# Altium schematic sheet 03 MCU.SchDoc
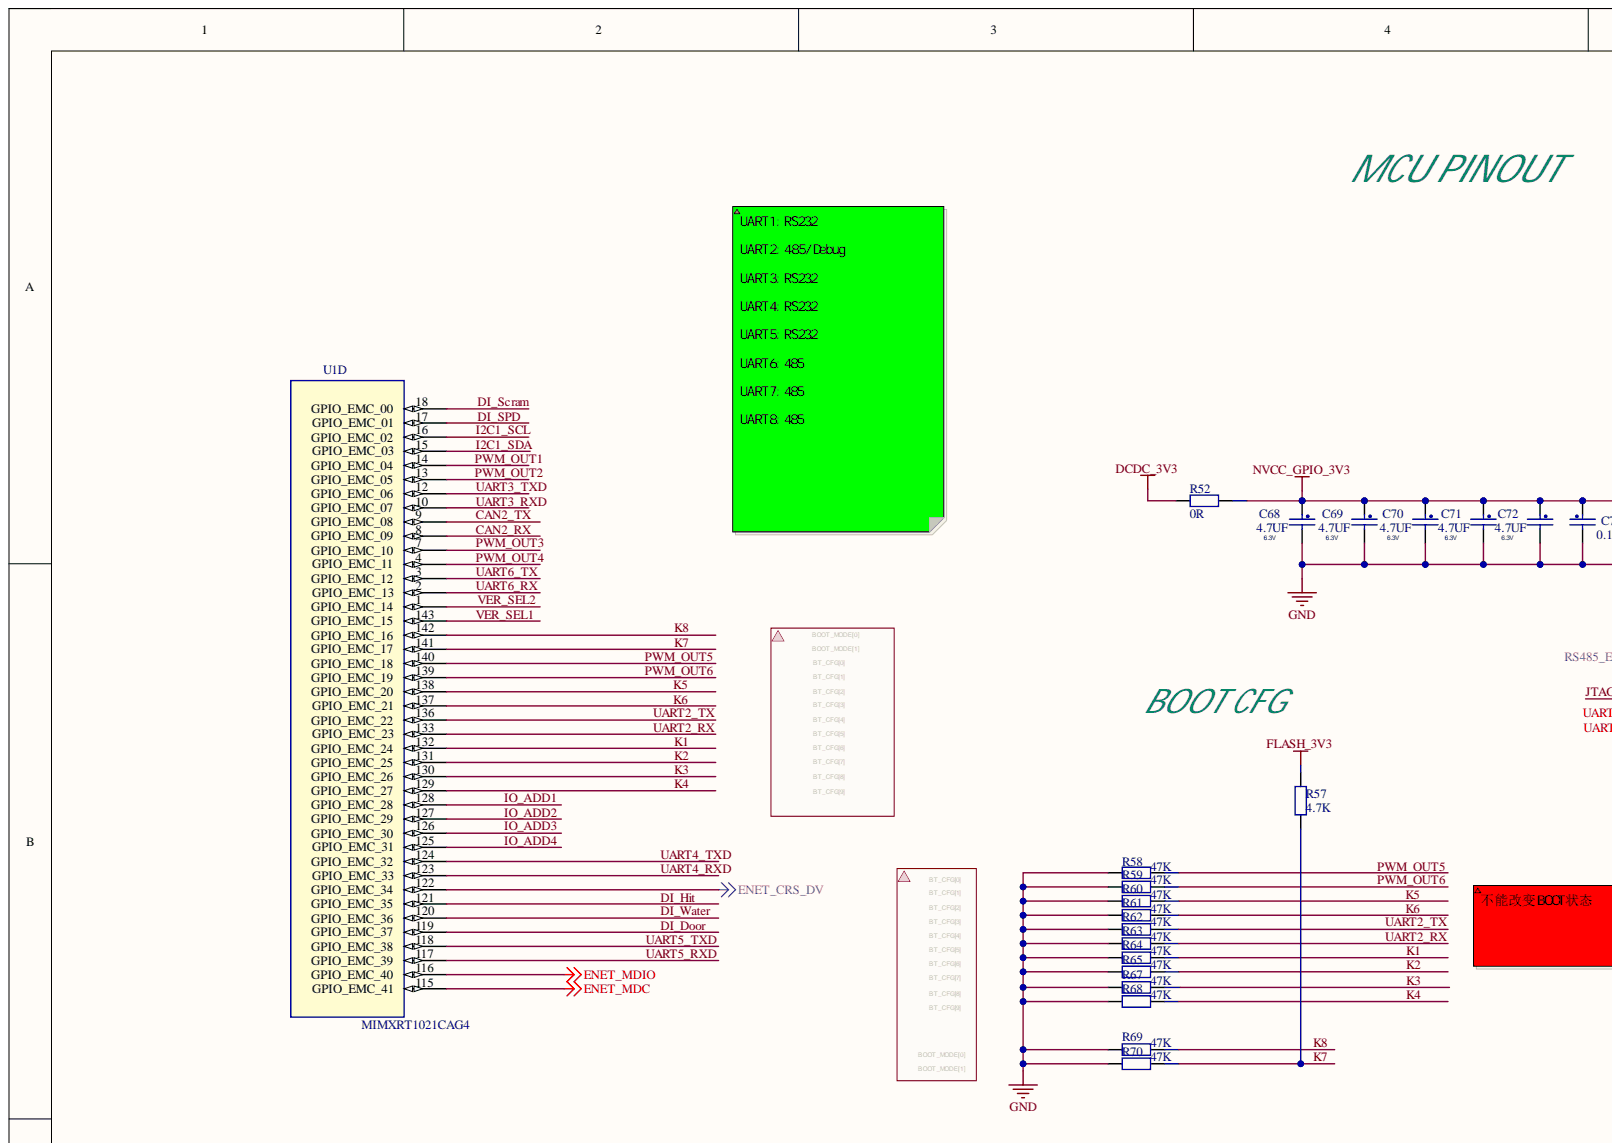
[diagram p0: 03 MCU.SchDoc, top left]
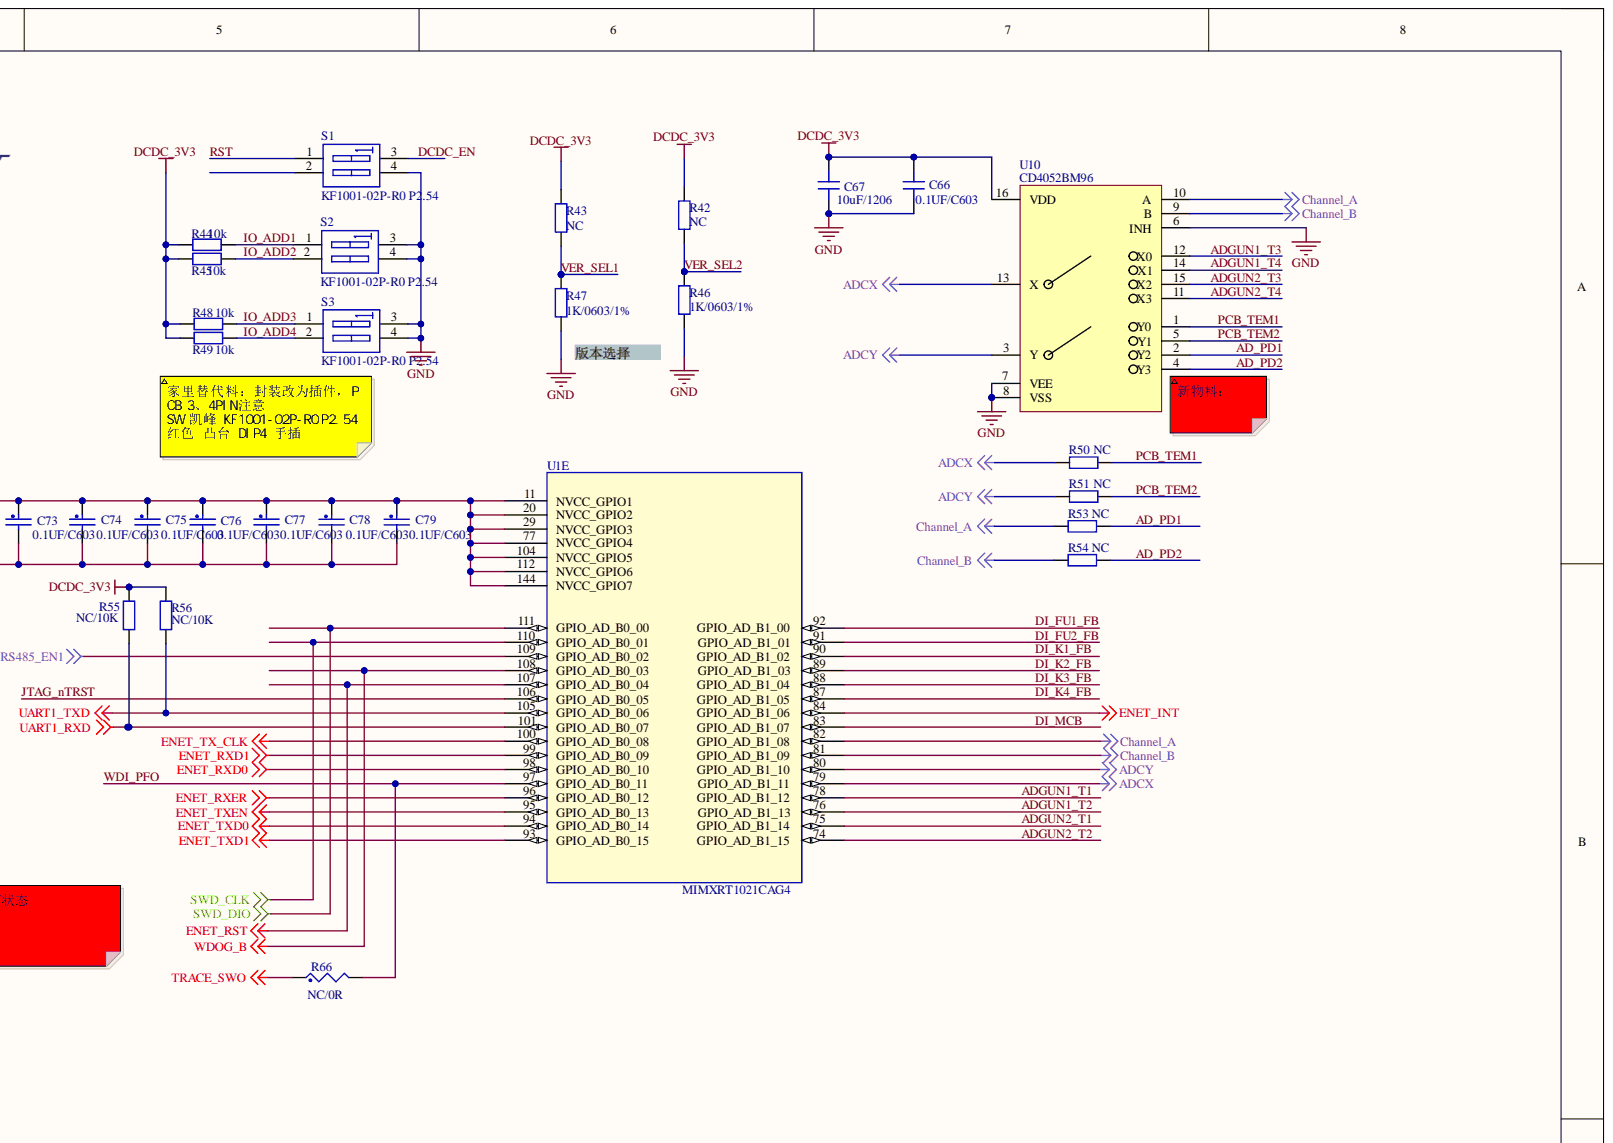
[diagram p1: 03 MCU.SchDoc, top right]
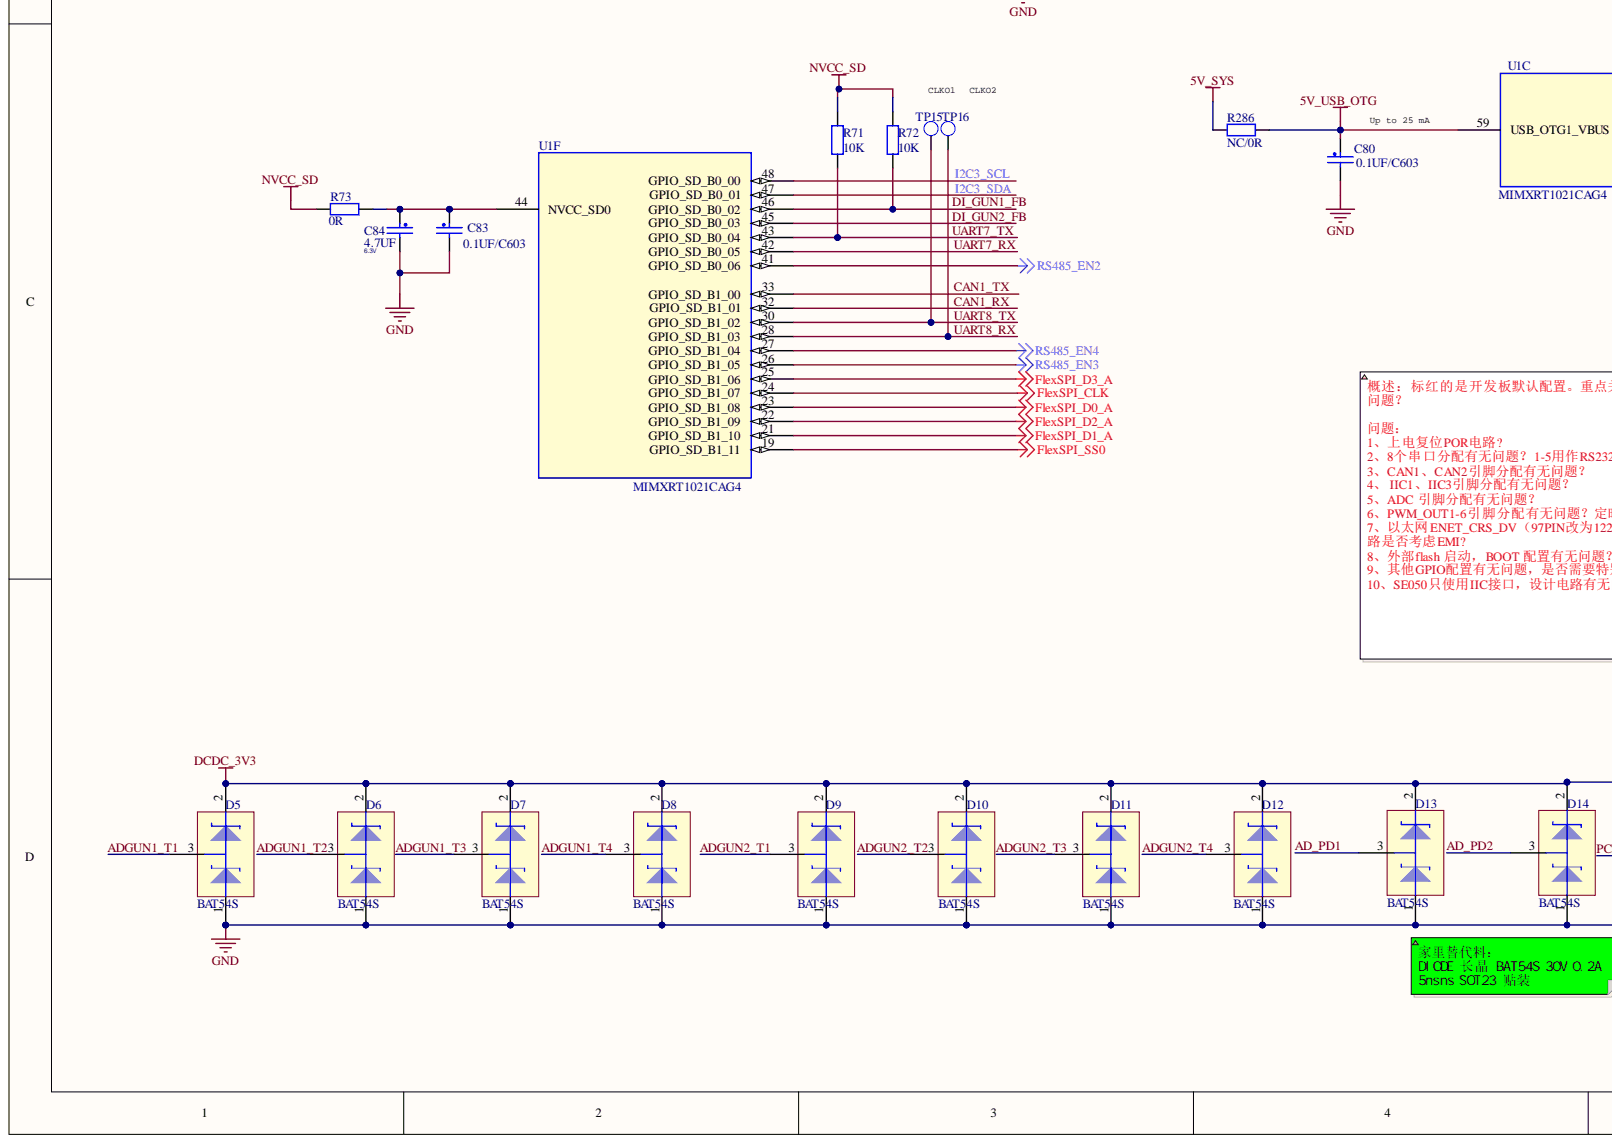
[diagram p2: 03 MCU.SchDoc, bottom left]
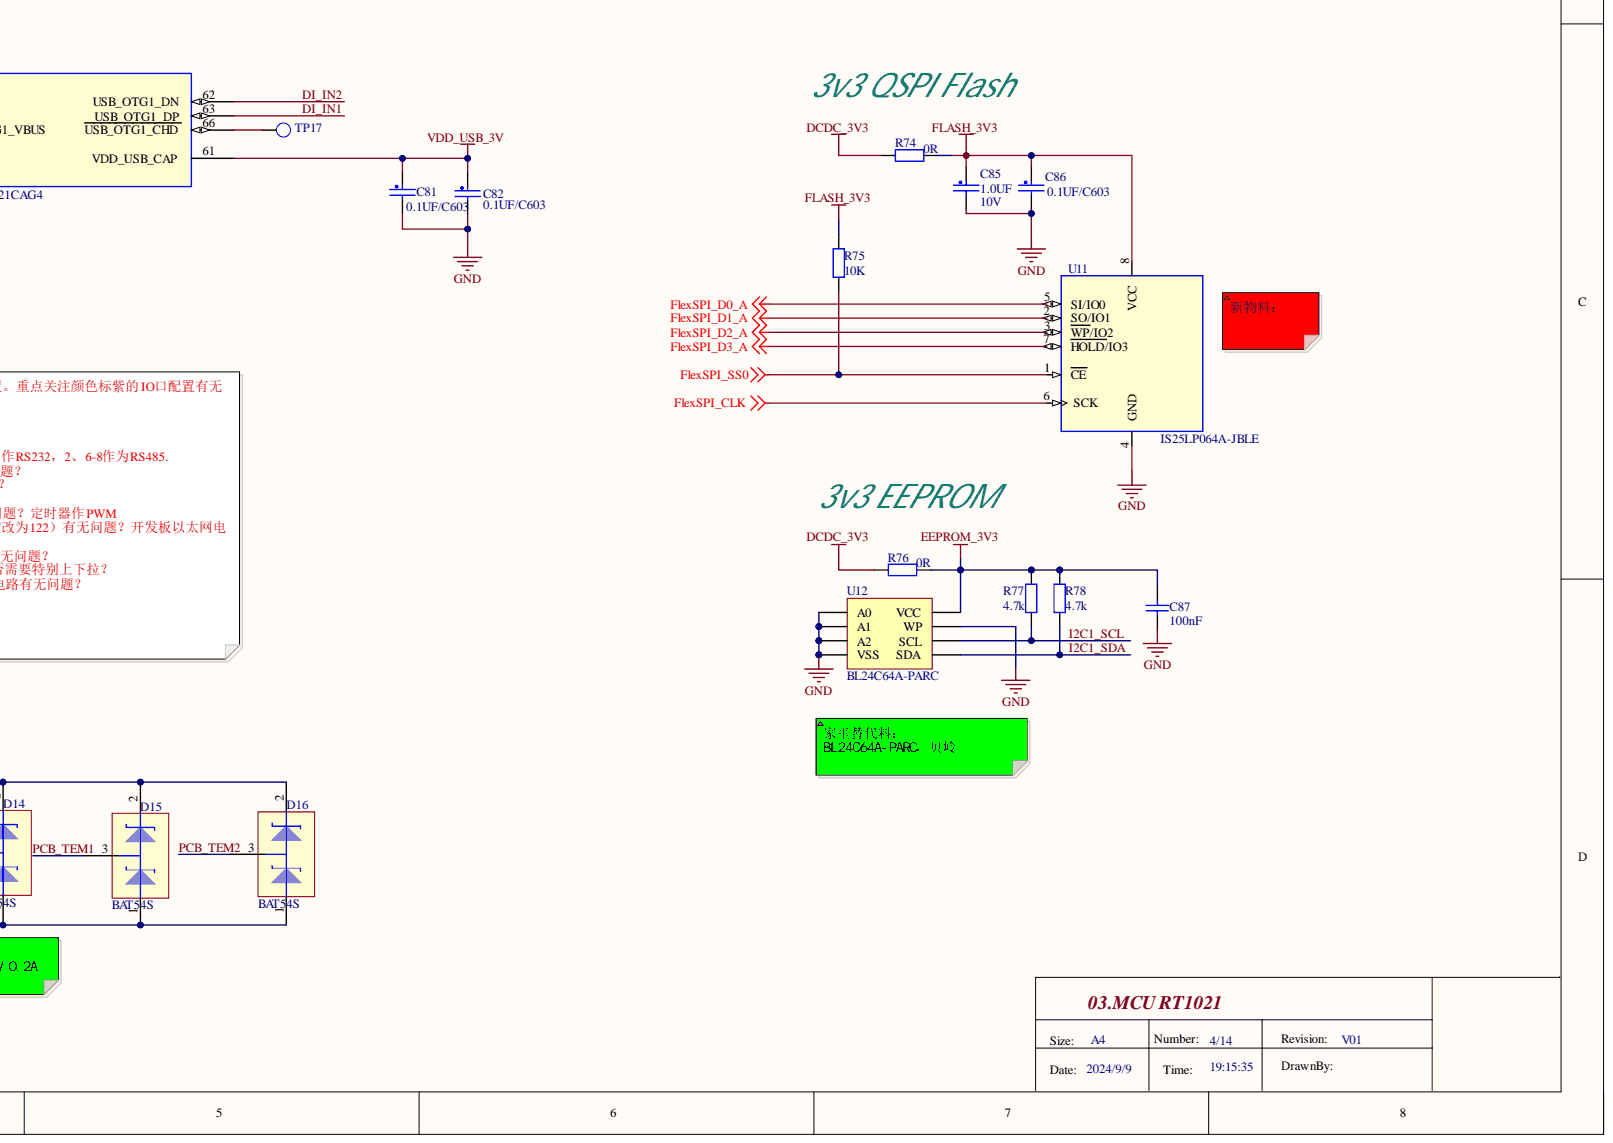
[diagram p3: 03 MCU.SchDoc, bottom right]

## Parts
C66  0.1UF/C603  [C0603]
C67  10uF/1206  [C1206]
C68  4.7UF  VOLTAGE: 6.3V  [C0805]
C69  4.7UF  VOLTAGE: 6.3V  [C0805]
C70  4.7UF  VOLTAGE: 6.3V  [C0805]
C71  4.7UF  VOLTAGE: 6.3V  [C0805]
C72  4.7UF  VOLTAGE: 6.3V  [C0805]
C73  0.1UF/C603  [C0603]
C74  0.1UF/C603  [C0603]
C75  0.1UF/C603  [C0603]
C76  0.1UF/C603  [C0603]
C77  0.1UF/C603  [C0603]
C78  0.1UF/C603  [C0603]
C79  0.1UF/C603  [C0603]
C80  0.1UF/C603  [C0603]
C81  0.1UF/C603  [C0603]
C82  0.1UF/C603  [C0603]
C83  0.1UF/C603  [C0603]
C84  4.7UF  VOLTAGE: 6.3V  [C0805]
C85  1.0UF  VOLTAGE: 10V  [C0805]
C86  0.1UF/C603  [C0603]
C87  100nF  [C0603]
D5  BAT54S  [SOT-23]
D6  BAT54S  [SOT-23]
D7  BAT54S  [SOT-23]
D8  BAT54S  [SOT-23]
D9  BAT54S  [SOT-23]
D10  BAT54S  [SOT-23]
D11  BAT54S  [SOT-23]
D12  BAT54S  [SOT-23]
D13  BAT54S  [SOT-23]
D14  BAT54S  [SOT-23]
D15  BAT54S  [SOT-23]
D16  BAT54S  [SOT-23]
R42  NC  [R0603]
R43  NC  [R0603]
R44  10k  [R0603]  (value not drawn)
R45  10k  [R0603]
R46  1K/0603/1%  [R0603]
R47  1K/0603/1%  [R0603]
R48  10k  [R0603]
R49  10k  [R0603]
R50  NC  [R0603]
R51  NC  [R0603]
R52  0R  [R0603]
R53  NC  [R0603]
R54  NC  [R0603]
R55  NC/10K  [R0603]
R56  NC/10K  [R0603]
R57  4.7K  [R0603]
R58  47K  [R0603]
R59  47K  [R0603]
R60  47K  [R0603]
R61  47K  [R0603]
R62  47K  [R0603]
R63  47K  [R0603]
R64  47K  [R0603]
R65  47K  [R0603]
R66  NC/0R  [R0603]
R67  47K  [R0603]
R68  47K  [R0603]
R69  47K  [R0603]
R70  47K  [R0603]
R71  10K  [R0603]
R72  10K  [R0603]
R73  0R  [R0603]
R74  0R  [R0603]
R75  10K  [R0603]
R76  0R  [R0603]
R77  4.7k  [R0603]
R78  4.7k  [R0603]
R286  NC/0R  [R0603]
S1  KF1001-02P-R0 P2.54  [DMR-02]
S2  KF1001-02P-R0 P2.54  [DMR-02]
S3  KF1001-02P-R0 P2.54  [DMR-02]
TP15  TP_35MIL  [TP12]  (value not drawn)
TP16  TP_35MIL  [TP12]  (value not drawn)
TP17  TP_35MIL  [TP12]  (value not drawn)
U1  MIMXRT1021CAG4  [LQFP-144_MIMXRT1021CAG4]  (parts C,D,E,F)
U10  CD4052BM96  [SOIC-16_150MIL]
U11  IS25LP064A-JBLE  [SOIC-8_208mil]
U12  BL24C64A-PARC  [SOIC-8_150mil]

## Nets
5V_SYS: R286.1
5V_USB_OTG: C80.1 U1.59(USB_OTG1_VBUS)
ADCX: R50.1 U1.79(GPIO_AD_B1_11) U10.13(X)
ADCY: R51.1 U1.80(GPIO_AD_B1_10) U10.3(Y)
Channel_A: R53.1 U1.82(GPIO_AD_B1_08) U10.10(A)
Channel_B: R54.1 U1.81(GPIO_AD_B1_09) U10.9(B)
DCDC_3V3: C66.2 C67.2 D5.2 D6.2 D7.2 D8.2 D9.2 D10.2 D11.2 D12.2 D13.2 D14.2 D15.2 D16.2 R42.1 R43.1 R44.1 R45.1 R48.1 R49.1 R52.1 R55.2 R56.2 R74.1 R76.1 U10.16(VDD)
EEPROM_3V3: C87.2 R76.2 R77.2 R78.2 U12.8(VCC)
ENET_CRS_DV: U1.122(GPIO_EMC_34)
ENET_INT: U1.84(GPIO_AD_B1_06)
ENET_MDC: U1.115(GPIO_EMC_41)
ENET_MDIO: U1.116(GPIO_EMC_40)
ENET_RST: U1.107(GPIO_AD_B0_04)
ENET_RXD0: U1.98(GPIO_AD_B0_10)
ENET_RXD1: U1.99(GPIO_AD_B0_09)
ENET_RXER: U1.96(GPIO_AD_B0_12)
ENET_TX_CLK: U1.100(GPIO_AD_B0_08)
ENET_TXD0: U1.94(GPIO_AD_B0_14)
ENET_TXD1: U1.93(GPIO_AD_B0_15)
ENET_TXEN: U1.95(GPIO_AD_B0_13)
FLASH_3V3: C85.1 C86.1 R57.2 R74.2 R75.2 U11.8(VCC)
FlexSPI_CLK: U1.24(GPIO_SD_B1_07) U11.6(SCK)
FlexSPI_D0_A: U1.23(GPIO_SD_B1_08) U11.5(SI/IO0)
FlexSPI_D1_A: U1.21(GPIO_SD_B1_10) U11.2(SO/IO1)
FlexSPI_D2_A: U1.22(GPIO_SD_B1_09) U11.3(WP/IO2)
FlexSPI_D3_A: U1.25(GPIO_SD_B1_06) U11.7(HOLD/IO3)
FlexSPI_SS0: R75.1 U1.19(GPIO_SD_B1_11) U11.1(CE)
GND: C66.1 C67.1 C68.2 C69.2 C70.2 C71.2 C72.2 C73.2 C74.2 C75.2 C76.2 C77.2 C78.2 C79.2 C80.2 C81.2 C82.2 C83.2 C84.2 C85.2 C86.2 C87.1 D5.1 D6.1 D7.1 D8.1 D9.1 D10.1 D11.1 D12.1 D13.1 D14.1 D15.1 D16.1 R46.2 R47.2 R58.1 R59.1 R60.1 R61.1 R62.1 R63.1 R64.1 R65.1 R67.1 R68.1 R69.1 R70.1 S1.4 S2.3 S2.4 S3.3 S3.4 U10.6(INH) U10.7(VEE) U10.8(VSS) U11.4(GND) U12.1(A0) U12.2(A1) U12.3(A2) U12.4(VSS) U12.7(WP)
NVCC_GPIO_3V3: C68.1 C69.1 C70.1 C71.1 C72.1 C73.1 C74.1 C75.1 C76.1 C77.1 C78.1 C79.1 R52.2 U1.11(NVCC_GPIO1) U1.20(NVCC_GPIO2) U1.29(NVCC_GPIO3) U1.77(NVCC_GPIO4) U1.104(NVCC_GPIO5) U1.112(NVCC_GPIO6) U1.144(NVCC_GPIO7)
NVCC_SD: R71.2 R72.2 R73.1
RS485_EN1: U1.109(GPIO_AD_B0_02)
RS485_EN2: U1.41(GPIO_SD_B0_06)
RS485_EN3: U1.26(GPIO_SD_B1_05)
RS485_EN4: U1.27(GPIO_SD_B1_04)
SWD_CLK: U1.110(GPIO_AD_B0_01)
SWD_DIO: U1.111(GPIO_AD_B0_00)
TRACE_SWO: R66.1
UART1_RXD: R55.1 U1.101(GPIO_AD_B0_07)
UART1_TXD: R56.1 U1.105(GPIO_AD_B0_06)
VDD_USB_3V: C81.1 C82.1 U1.61(VDD_USB_CAP)
WDOG_B: U1.108(GPIO_AD_B0_03)
AD_PD1: D13.3 R53.2 U10.2(Y2)
AD_PD2: D14.3 R54.2 U10.4(Y3)
ADGUN1_T1: D5.3 U1.78(GPIO_AD_B1_12)
ADGUN1_T2: D6.3 U1.76(GPIO_AD_B1_13)
ADGUN1_T3: D7.3 U10.12(X0)
ADGUN1_T4: D8.3 U10.14(X1)
ADGUN2_T1: D9.3 U1.75(GPIO_AD_B1_14)
ADGUN2_T2: D10.3 U1.74(GPIO_AD_B1_15)
ADGUN2_T3: D11.3 U10.15(X2)
ADGUN2_T4: D12.3 U10.11(X3)
CAN1_RX: U1.32(GPIO_SD_B1_01)
CAN1_TX: U1.33(GPIO_SD_B1_00)
CAN2_RX: U1.8(GPIO_EMC_09)
CAN2_TX: U1.9(GPIO_EMC_08)
DCDC_EN: S1.3
DI_Door: U1.119(GPIO_EMC_37)
DI_FU1_FB: U1.92(GPIO_AD_B1_00)
DI_FU2_FB: U1.91(GPIO_AD_B1_01)
DI_GUN1_FB: R72.1 U1.46(GPIO_SD_B0_02)
DI_GUN2_FB: U1.45(GPIO_SD_B0_03)
DI_Hit: U1.121(GPIO_EMC_35)
DI_IN1: U1.63(USB_OTG1_DP)
DI_IN2: U1.62(USB_OTG1_DN)
DI_K1_FB: U1.90(GPIO_AD_B1_02)
DI_K2_FB: U1.89(GPIO_AD_B1_03)
DI_K3_FB: U1.88(GPIO_AD_B1_04)
DI_K4_FB: U1.87(GPIO_AD_B1_05)
DI_MCB: U1.83(GPIO_AD_B1_07)
DI_Scram: U1.18(GPIO_EMC_00)
DI_SPD: U1.17(GPIO_EMC_01)
DI_Water: U1.120(GPIO_EMC_36)
I2C1_SCL: R77.1 U1.16(GPIO_EMC_02) U12.6(SCL)
I2C1_SDA: R78.1 U1.15(GPIO_EMC_03) U12.5(SDA)
I2C3_SCL: U1.48(GPIO_SD_B0_00)
I2C3_SDA: U1.47(GPIO_SD_B0_01)
IO_ADD1: R44.2 S2.1 U1.128(GPIO_EMC_28)
IO_ADD2: R45.2 S2.2 U1.127(GPIO_EMC_29)
IO_ADD3: R48.2 S3.1 U1.126(GPIO_EMC_30)
IO_ADD4: R49.2 S3.2 U1.125(GPIO_EMC_31)
JTAG_nTRST: U1.106(GPIO_AD_B0_05)
K1: R64.2 U1.132(GPIO_EMC_24)
K2: R65.2 U1.131(GPIO_EMC_25)
K3: R67.2 U1.130(GPIO_EMC_26)
K4: R68.2 U1.129(GPIO_EMC_27)
K5: R60.2 U1.138(GPIO_EMC_20)
K6: R61.2 U1.137(GPIO_EMC_21)
K7: R57.1 R70.2 U1.141(GPIO_EMC_17)
K8: R69.2 U1.142(GPIO_EMC_16)
PCB_TEM1: D15.3 R50.2 U10.1(Y0)
PCB_TEM2: D16.3 R51.2 U10.5(Y1)
PWM_OUT1: U1.14(GPIO_EMC_04)
PWM_OUT2: U1.13(GPIO_EMC_05)
PWM_OUT3: U1.7(GPIO_EMC_10)
PWM_OUT4: U1.4(GPIO_EMC_11)
PWM_OUT5: R58.2 U1.140(GPIO_EMC_18)
PWM_OUT6: R59.2 U1.139(GPIO_EMC_19)
RST: S1.1
UART2_RX: R63.2 U1.133(GPIO_EMC_23)
UART2_TX: R62.2 U1.136(GPIO_EMC_22)
UART3_RXD: U1.10(GPIO_EMC_07)
UART3_TXD: U1.12(GPIO_EMC_06)
UART4_RXD: U1.123(GPIO_EMC_33)
UART4_TXD: U1.124(GPIO_EMC_32)
UART5_RXD: U1.117(GPIO_EMC_39)
UART5_TXD: U1.118(GPIO_EMC_38)
UART6_RX: U1.2(GPIO_EMC_13)
UART6_TX: U1.3(GPIO_EMC_12)
UART7_RX: U1.42(GPIO_SD_B0_05)
UART7_TX: R71.1 U1.43(GPIO_SD_B0_04)
UART8_RX: TP16.1 U1.28(GPIO_SD_B1_03)
UART8_TX: TP15.1 U1.30(GPIO_SD_B1_02)
VER_SEL1: R43.2 R47.1 U1.143(GPIO_EMC_15)
VER_SEL2: R42.2 R46.1 U1.1(GPIO_EMC_14)
WDI_PFO: R66.2 U1.97(GPIO_AD_B0_11)
NetC83_1: C83.1 C84.1 R73.2 U1.44(NVCC_SD0)
NetTP17_1: TP17.1 U1.66(USB_OTG1_CHD)
BOOT_MODE[0]:
BOOT_MODE[1]:
BT_CFG[0]:
BT_CFG[1]:
BT_CFG[2]:
BT_CFG[3]:
BT_CFG[4]:
BT_CFG[5]:
BT_CFG[6]:
BT_CFG[7]:
BT_CFG[8]:
BT_CFG[9]:

Not connected: R286 2; S1 2

## Library data of the parts
C66 = 100nF_50V_10%: CAP CER 0.1UF 10V 10% X5R 0603; ERP code: ELC.0xxx; 品牌: YAGEO; 型号规格: CC0402KRX7R9BB104; 焊接类型: SMT; Component Kind: Standard; Library Name: Component Library.IntLib
C67 = 22uF_25V_10%_X7R_1210: 贴片电容,10uF-25V-1206-X5R-10%; 品牌: YAGEO; 型号规格: CC1206KKX5R8BB106; 焊接类型: SMT; Component Kind: Standard; ERP code: ELC.0293; Library Name: Component Library.IntLib
C68-C72 C84 = CAP_NP: CAP CER 4.7UF 6.3V 20% X5R 0402; AGILE_PN: 150-78463; CAD_APPROVED: Yes; CLASS: DISCRETE; FPLIST: CC0402_25,CC0402_HD,0402_CC; MFG_NAME01: TDK; MFG_PN01: C1005X5R0J475M050BC; PCB Footprint: 0402_CC; Component Kind: Standard; HEIGHT: 0.65MM; ROHS: Yes; Tolerance: 20%; voltage rating: 6.3V
C73-C83 C86 = CAP_NP: CAP CER 0.1UF 10V 10% X5R 0603; AGILE_PN: 150-75634; CAD_APPROVED: Yes; CLASS: DISCRETE; FPLIST: CC0402_25,CC0402_HD,0402_CC; MFG_NAME01: KEMET; MFG_PN01: C0402C104K8PAC; PCB Footprint: CC0402_25; Component Kind: Standard; HEIGHT: 0.64MM; ROHS: Yes; Tolerance: 10%; VOLTAGE: 10V
C85 = CAP_NP: CAP CER 1.0UF 10V 10% X5R 0402; AGILE_PN: 150-75772; CAD_APPROVED: Yes; CLASS: DISCRETE; FPLIST: CC0402_25,CC0402_HD,0402_CC; MFG_NAME01: YAGEO AMERICA; MFG_PN01: CC0402KRX5R6BB105; PCB Footprint: 0402_CC; Component Kind: Standard; HEIGHT: 0.6MM; ROHS: Yes; voltage rating: 10V
C87 = Cap: 无极性电容; 厂: Samsung; Component Kind: Standard; Library Name: 超超的封装库.IntLib
D5-D16 = BAT54SLT1G: DIODE 长晶 BAT54S 30V 0.2A 5nsns SOT23 贴装; Component Kind: Standard; Library Name: DH_Device.SchLib
R42 R43 = RES: 焊接类型: SMT; Component Kind: Standard; Library Name: DH_Device.SchLib
R44 R45 R48-R65 R67-R78 R286 = Res: 电阻器; 厂: Samsung; Component Kind: Standard; Library Name: 超超的封装库.IntLib
R46 R47 = RES: 贴片电阻,1K-1/10W-0603-1%; 品牌: YAGEO; 型号规格: RC0603FR-071KL; 焊接类型: SMT; Component Kind: Standard; ERP code: ELC.0269; Library Name: DH_Device.SchLib
R66 = RES_Dup1: RES MF ZERO OHM 1/16W 5% 0402; AGILE_PN: 470-75394; CAD_APPROVED: Yes; CLASS: DISCRETE; FPLIST: RC0402_25,RC0402_HD,RC0402_X2,0402_CC; MFG_NAME01: ROHM; MFG_PN01: MCR01MZPJ000; PCB Footprint: 0402_CC; Component Kind: Standard; HEIGHT: 0.45 MM; ROHS: Yes; Tolerance: 5%
S1-S3 = SW DIP-2: SW 凯峰 KF1001-02P-R0 P2.54 红色 凸台 DIP4 手插; Component Kind: Standard; LatestRevisionDate: 17-Jul-2002; LatestRevisionNote: Re-released for DXP Platform.; Library Name: Miscellaneous Devices.IntLib; PackageReference: DIP-4; Published: 24-Mar-1999; Simulation: dpsw2; Publisher: Altium Limited
TP15-TP17 = T POINT R: TEST POINT PAD .035 SMT, no part to order; AGILE_PN: 510-75618; CAD_APPROVED: Yes; CLASS: IC; PCB Footprint: testpoint_35mil; Component Kind: Standard; HEIGHT: 0.1MM; ROHS: Yes
U1 = IMXRT1020_LQFP144P: IC CPU ARM Cortex-M7 500MHZ 1.3V LQFP144; AGILE_PN: 330-77054; CAD_APPROVED: Yes; MFG_NAME01: NXP SEMICONDUCTORS; MFG_PN01: MIMXRT1021DAG5A; PCB Footprint: LQFP144_0P5_20X20MM; SPLIT_INST: TRUE; SWAP_INFO: (S1+S2+S3+S4+S5+S6); CLASS: IC; Component Kind: Standard; HEIGHT: 1.6 MM; ROHS: Yes
U10 = CD4052BM96: 模拟通道选择器-两路四选一; 品牌: TI; 焊接类型: SMT; Component Kind: Standard; ERP code: ELC.0077; Library Name: DH_Device_V1.SchLib; 型号规格: CD4052BM96
U11 = IS25LP064A_8P: IC MEM FLASH QPI 64Mb 2.7-3.6V SO8W; AGILE_PN: 342-00722; CAD_APPROVED: Yes; MFG_NAME01: ISSI; PCB Footprint: so8_w; Temp_Range: -40C to +105C; CLASS: IC; Component Kind: Standard; HEIGHT: 2.16MM; MFG_PN01: IS25LP064A-JBLE; ROHS: Yes
U12 = 24AA32A: BL24C64A-PARC，贝岭; Component Kind: Standard; Library Name: zd3_400 V1.0.SCHLIB
